# Altium schematic sheet POWER.SchDoc(POWER)
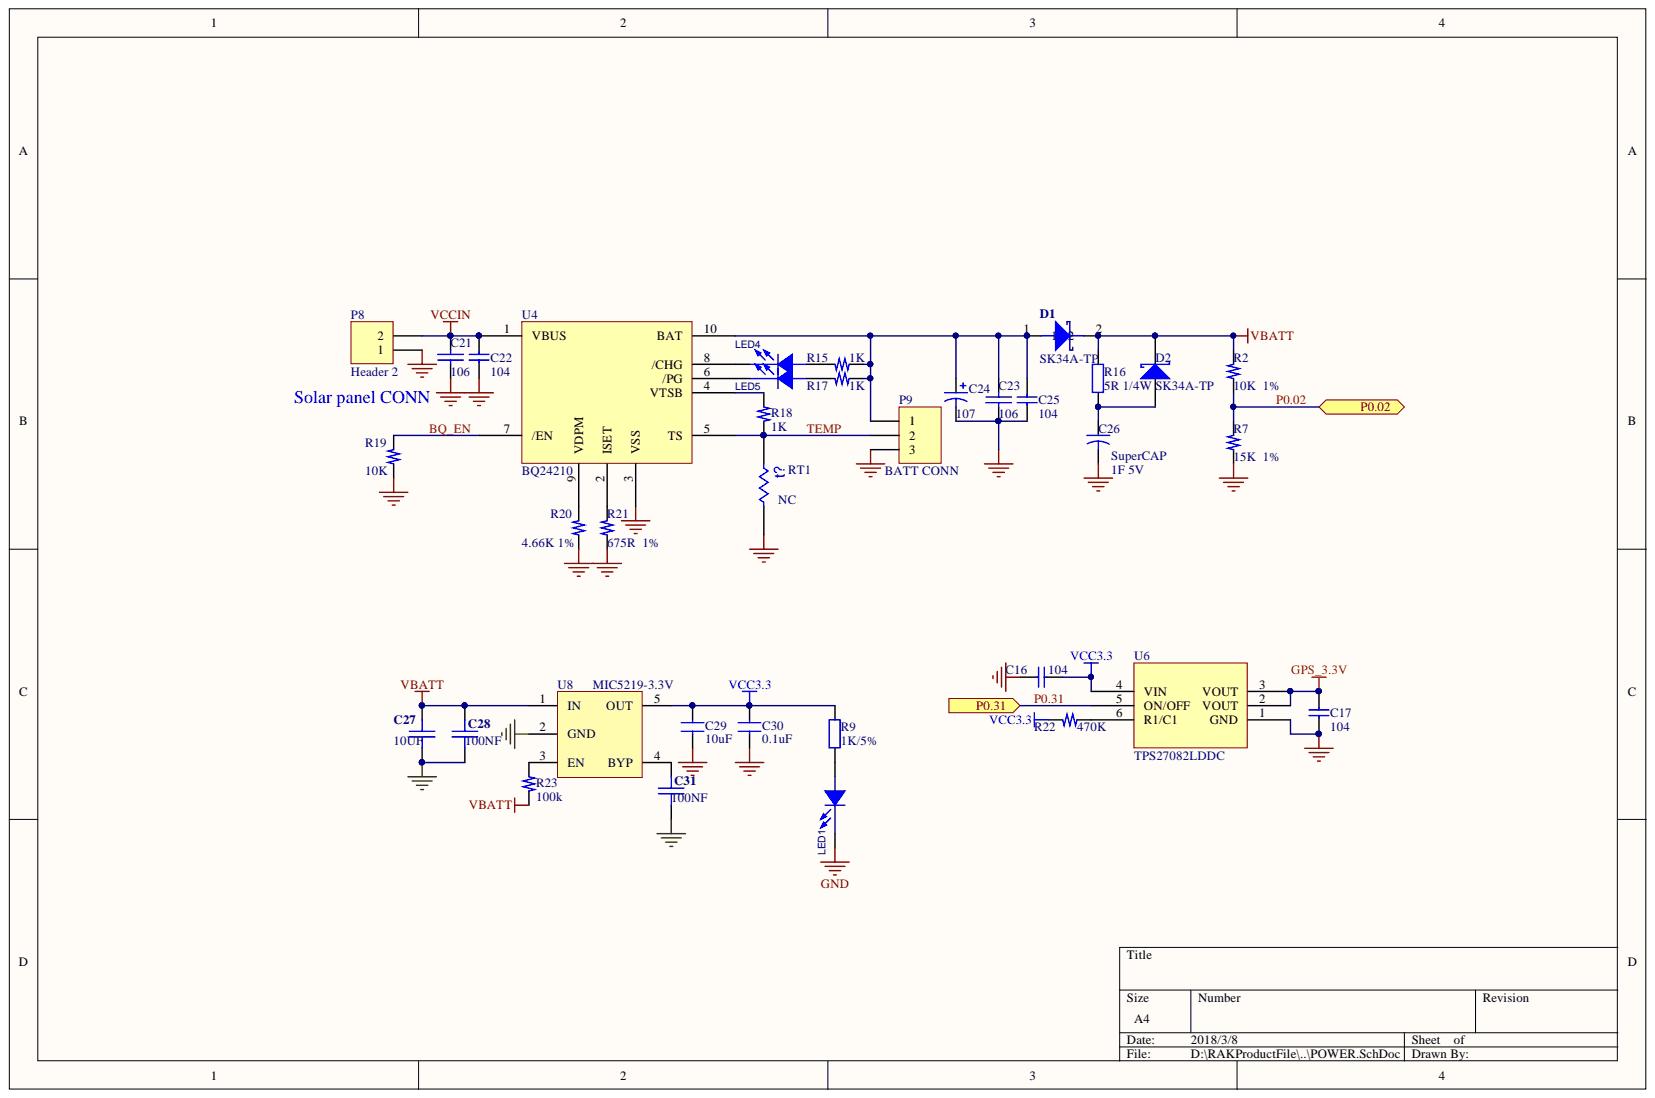
[diagram p0: POWER.SchDoc]

## Parts
C16  104  [CAPC1005X04L]
C17  104  [CAPC1005X04L]
C21  106  [CAPC1608X06L]
C22  104  [CAPC1005X04L]
C23  106  [CAPC1608X06L]
C24  value 107  [TAN-B-3528]  (hidden comment: Cap Pol1)
C25  104  [CAPC1005X04L]
C26  SuperCAP  value 1F 5V  [SE-5R5-Z105VY]
C27  10UF  [CAPC1608X06L]
C28  100NF  [CAPC1005X04L]
C29  10uF  [CAPC1608X06L]
C30  0.1uF  [CAPC1005X04L]
C31  100NF  [CAPC1005X04L]
D1  value SK34A-TP  [sod-sk34a]  (hidden comment: DIODE SCHOTTKY)
D2  SK34A-TP  [sod-sk34a]
LED1  (no value)  [LED0603]
LED4  (no value)  [LED0603]
LED5  (no value)  [LED0603]
P8  Header 2  [SIP2-2]
P9  BATT CONN  [SIP3-2]
R2  10K  1%  [CAPC1005X04L]
R7  15K  1%  [CAPC1005X04L]
R9  1K/5%  [CAPC1005X04L]
R15  1K  [CAPC1005X04L]
R16  value 5R 1/4W  [1206r]  (hidden comment: 10k)
R17  1K  [CAPC1005X04L]
R18  1K  [CAPC1005X04L]
R19  10K  [CAPC1005X04L]
R20  4.66K 1%  [CAPC1005X04L]
R21  675R  1%  [CAPC1005X04L]
R22  470K  [CAPC1005X04L]
R23  100k  [CAPC1005X04L]
RT1  NC  [CAPC1005X04L]
U4  BQ24210  [PWSON-N10]
U6  TPS27082LDDC  [TPS27082]
U8  MIC5219-3.3V  [SOT25]

## Ports
P0.02, P0.31

## Nets
GND: C16.2 C17.2 C21.1 C22.2 C23.1 C24.2 C25.2 C26.2 C27.2 C28.2 C29.2 C30.2 C31.2 LED1.2 P8.1 P9.3 R7.1 R19.1 R20.1 R21.1 RT1.2 U4.3(VSS) U6.1(GND) U8.2(GND)
GPS_3.3V: C17.1 U6.2(VOUT) U6.3(VOUT)
VBATT: C27.1 C28.1 D1.2 D2.2 R2.2 R16.1 R23.2 U8.1(IN)
VCC3.3: C16.1 C29.1 C30.1 R9.2 R22.2 U6.4(VIN) U8.5(OUT)
VCCIN: C21.2 C22.1 P8.2 U4.1(VBUS)
BQ_EN: R19.2 U4.7(/EN)
P0.02: R2.1 R7.2 ; port P0.02
P0.31: U6.5(ON/OFF) ; port P0.31
TEMP: P9.2 R18.2 RT1.1 U4.5(TS)
NetC23_2: C23.2 C24.1 C25.1 D1.1 P9.1 R15.1 R17.1 U4.10(BAT)
NetC26_1: C26.1 D2.1 R16.2
NetC31_1: C31.1 U8.4(BYP)
NetLED1_1: LED1.1 R9.1
NetLED4_1: LED4.1 R15.2
NetLED4_2: LED4.2 U4.8(/CHG)
NetLED5_1: LED5.1 R17.2
NetLED5_2: LED5.2 U4.6(/PG)
NetR18_1: R18.1 U4.4(VTSB)
NetR20_2: R20.2 U4.9(VDPM)
NetR21_2: R21.2 U4.2(ISET)
NetR22_1: R22.1 U6.6(R1/C1)
NetR23_1: R23.1 U8.3(EN)

## Library data of the parts
C16 C17 C22 C25 = C0603-4?: Capacitor, X5R, ?0%; Artikkelnr Noca: 20069060; Artikkelnr Simpro: N.A.; Database Table Name: Capacitors$; Note: 6.3V; Value: 4.7礔; Component Kind: Standard; Footprint Doc: 0603; LatestRevisionDate: 2009-11-23; Library Name: Nordic Passive DB.DbLib; Part Number: C0603-4?; Pin Count: 2; Published: 2009-05-08; Publisher: Nordic Semiconductor
C21 C23 C29 C30 = CAP: Capacitor; Component Kind: Standard
C24 = Cap Pol1: Polarized Capacitor (Radial); Component Kind: Standard; LatestRevisionDate: 17-Jul-2002; LatestRevisionNote: Re-released for DXP Platform.; Library Name: Miscellaneous Devices.IntLib; PackageReference: RB7.6-15; Published: 8-Jun-2000; Signal Integrity: Cap Pol; Simulation: CAP; Publisher: Altium Limited
C26 = Cap2: Capacitor; Component Kind: Standard; Library Name: Miscellaneous Devices.IntLib; PackageReference: CAPR5-4X5; Published: 23-Sep-2002; Signal Integrity: Cap; Simulation: CAP; Publisher: Altium Limited
C27 = CAP1: 106,10μF,±10%,10V,X5R,0805,RoHS; 名称: 电容; 封装: 0805; 物料代码: 1.02.02.0055; 设备封装名称: C0805_106_X5R_10V_10%; 贴片和直插: SMT; Component Kind: Standard; ComponentLink1Description: ErpDataInfo; ComponentLink1URL: http://erp.zlgmcu.com/ErpExt/ErpData/ListMateriel.aspx?pkey=1.02.02.0055; RoHS: RoHS; Value: 106,10μF; 型号: 106,10μF,±10%,10V,X5R
C28 C31 = CAP1: 104,±10%,50V,X7R,0603,*,RoHS; 名称: 电容; 器件优选方案: 优先选用; 封装: 0603; 物料代码: 1.02.01.0032; 设备封装名称: C0603_104_X7R_50V_10%; 贴片和直插: SMT; Component Kind: Standard; ComponentLink1Description: ErpDataInfo; ComponentLink1URL: http://erp.zlgmcu.com/ErpExt/ErpData/ListMateriel.aspx?pkey=1.02.01.0032; RoHS: RoHS; Value: 104,±10%; 型号: 104,±10%,50V,X7R
D1 = 1.04.07.0021: SK34A-TP,DO-214AC,MCC,RoHS; Database Table Name: VErpDataInfo; 名称: 二极管; 设备封装名称: DO-214AC_SK34A; 贴片和直插: SMT; Component Kind: Standard; ComponentLink1Description: ErpDataInfo; ComponentLink1URL: http://erp.zlgmcu.com/ErpExt/ErpData/ListMateriel.aspx?pkey=1.04.07.0021; Library Name: ErpMaterialData.DbLib; RoHS: RoHS; 厂商: MCC; 型号: SK34A-TP; 封装: DO-214AC; 物料代码: 1.04.07.0021
D2 = D Schottky: Schottky Diode; Code - JEDEC: DO-214-AA; Component Kind: Standard; Published: 8-Jun-2000; Revision: July-2002: Re-released for DXP Platform.; Publisher: Altium Limited
LED1 LED4 LED5 = LED: Component Kind: Standard; Library Name: NUC950_EVB_V13.SCHLIB
P8 = Header 2: Header, 2-Pin; Component Kind: Standard; LatestRevisionDate: 17-Jul-2002; LatestRevisionNote: Re-released for DXP Platform.; Library Name: Miscellaneous Connectors.IntLib; Signal Integrity: Connector; Publisher: Altium Limited
P9 = Header 3H: Header, 3-Pin, Right Angle; Component Kind: Standard; LatestRevisionDate: 17-Jul-2002; LatestRevisionNote: Re-released for DXP Platform.; Library Name: Miscellaneous Connectors.IntLib; Signal Integrity: Connector; Publisher: Altium Limited
R2 R7 R15 R17-R23 = 电阻: Component Kind: Standard
R9 = Res2: Resistor; Value: 10K; Component Kind: Standard; LatestRevisionDate: 17-Jul-2002; LatestRevisionNote: Re-released for DXP Platform.; PackageReference: AXIAL-0.4; Published: 8-Jun-2000; Publisher: Altium Limited
R16 = Resistor: Resistor SMD - IEC symbol version; Component Kind: Standard; LatestRevisionDate: 27-Jul-20  -  Semtech; Library Name: SX127x_e243v03a.SchLib
RT1 = Res Thermal: Thermistor; Component Kind: Standard; LatestRevisionDate: 04-Mar-2005; LatestRevisionNote: PCB Footprint replaced.; Library Name: Miscellaneous Devices.IntLib; PackageReference: 6-0805; Published: 24-Mar-1999; Publisher: Altium Limited
U8 = MIC5219: 3.3V,LDO,MIC5219; Component Kind: Standard; Library Name: IOT_BTS_Sch.SchLib
U4 = BQ24210: Component Kind: Standard; Library Name: Schlib1.SchLib
U6 = TPS27082LDDC: Component Kind: Standard; Library Name: Schlib1.SchLib
